# Altium schematic sheet RAM.SchDoc
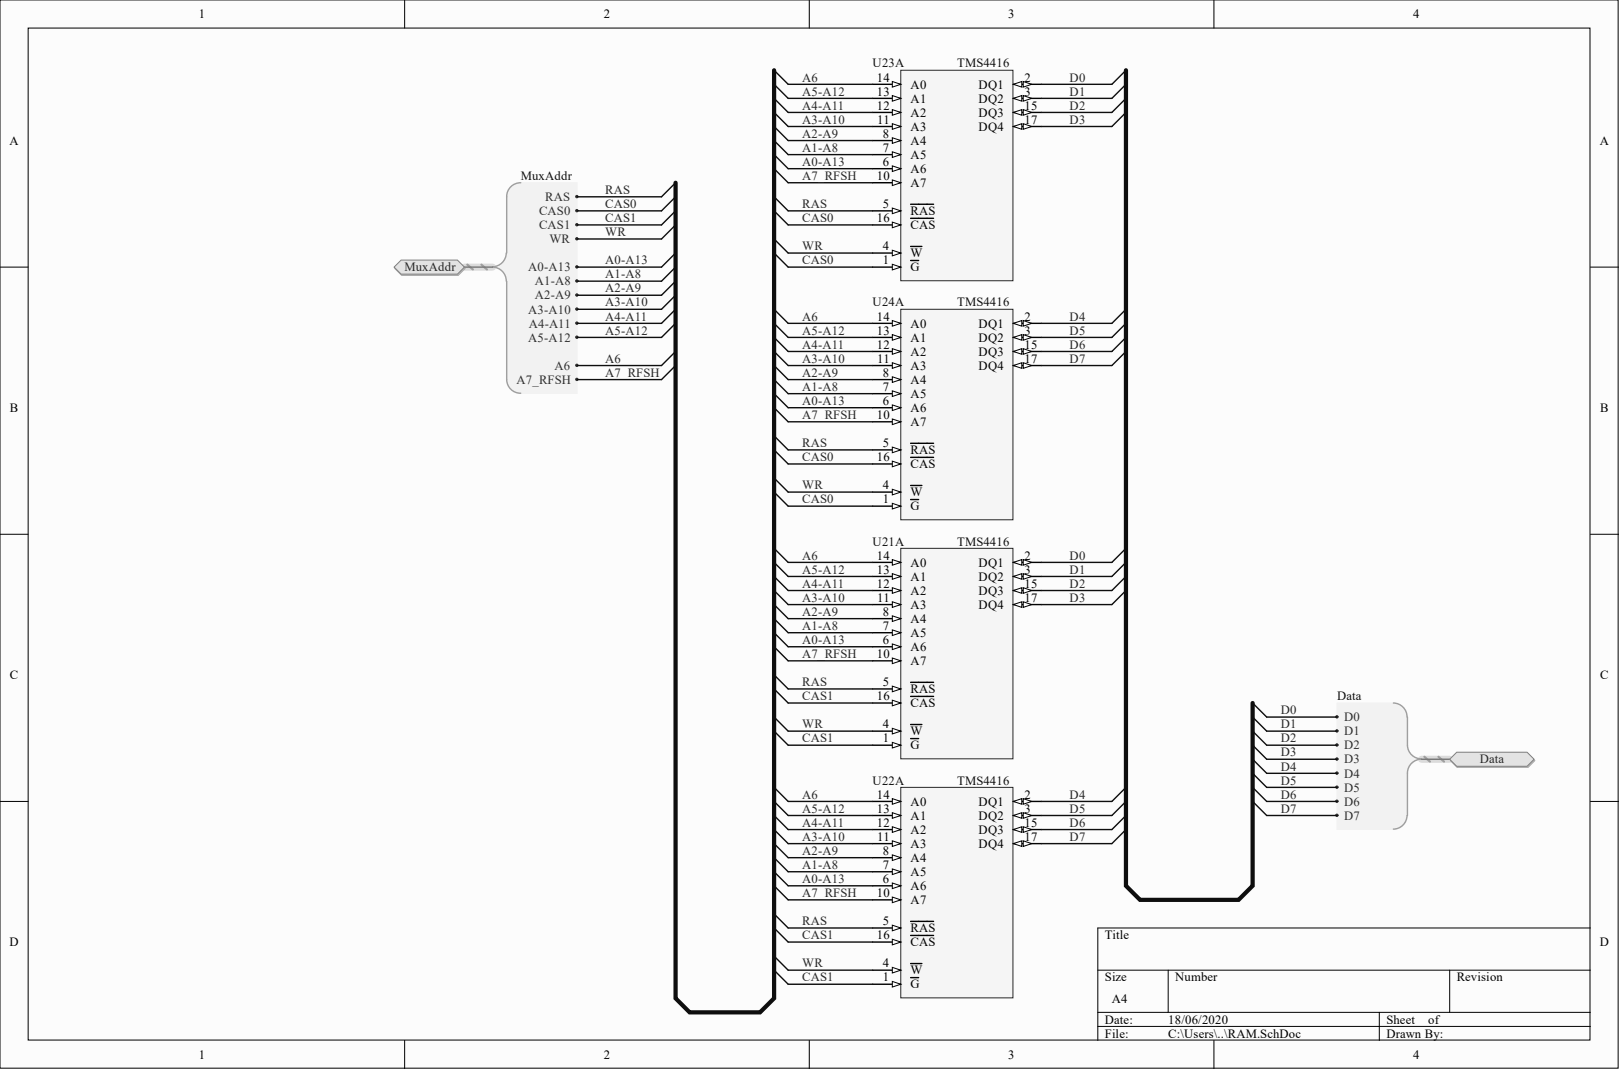
[diagram p0: RAM.SchDoc]

## Parts
U21  TMS4416  [PDIP18]  (part A)
U22  TMS4416  [PDIP18]  (part A)
U23  TMS4416  [PDIP18]  (part A)
U24  TMS4416  [PDIP18]  (part A)

## Ports
DATA, DATA.D0, DATA.D1, DATA.D2, DATA.D3, DATA.D4, DATA.D5, DATA.D6, DATA.D7, MUXADDR, MUXADDR.A0-A13, MUXADDR.A1-A8, MUXADDR.A2-A9, MUXADDR.A3-A10, MUXADDR.A4-A11, MUXADDR.A5-A12, MUXADDR.A6, MUXADDR.A7_RFSH, MUXADDR.CAS0, MUXADDR.CAS1, MUXADDR.RAS, MUXADDR.WR

## Nets
A0-A13: U21.6(A6) U22.6(A6) U23.6(A6) U24.6(A6) ; port MUXADDR
A1-A8: U21.7(A5) U22.7(A5) U23.7(A5) U24.7(A5) ; port MUXADDR
A2-A9: U21.8(A4) U22.8(A4) U23.8(A4) U24.8(A4) ; port MUXADDR
A3-A10: U21.11(A3) U22.11(A3) U23.11(A3) U24.11(A3) ; port MUXADDR
A4-A11: U21.12(A2) U22.12(A2) U23.12(A2) U24.12(A2) ; port MUXADDR
A5-A12: U21.13(A1) U22.13(A1) U23.13(A1) U24.13(A1) ; port MUXADDR
A6: U21.14(A0) U22.14(A0) U23.14(A0) U24.14(A0) ; port MUXADDR
A7_RFSH: U21.10(A7) U22.10(A7) U23.10(A7) U24.10(A7) ; port MUXADDR
CAS0: U23.1(G) U23.16(CAS) U24.1(G) U24.16(CAS) ; port MUXADDR
CAS1: U21.1(G) U21.16(CAS) U22.1(G) U22.16(CAS) ; port MUXADDR
D0: U21.2(DQ1) U23.2(DQ1) ; port DATA
D1: U21.3(DQ2) U23.3(DQ2) ; port DATA
D2: U21.15(DQ3) U23.15(DQ3) ; port DATA
D3: U21.17(DQ4) U23.17(DQ4) ; port DATA
D4: U22.2(DQ1) U24.2(DQ1) ; port DATA
D5: U22.3(DQ2) U24.3(DQ2) ; port DATA
D6: U22.15(DQ3) U24.15(DQ3) ; port DATA
D7: U22.17(DQ4) U24.17(DQ4) ; port DATA
RAS: U21.5(RAS) U22.5(RAS) U23.5(RAS) U24.5(RAS) ; port MUXADDR
WR: U21.4(W) U22.4(W) U23.4(W) U24.4(W) ; port MUXADDR
N00077:
N00078:

## Library data of the parts
U21-U24 = TMS4416: 16,348 Word by 4-bit Dynamic RAM; Component Kind: Standard; Library Name: Texas Instruments.IntLib
